# Altium schematic sheet COMMUNICATION.SchDoc
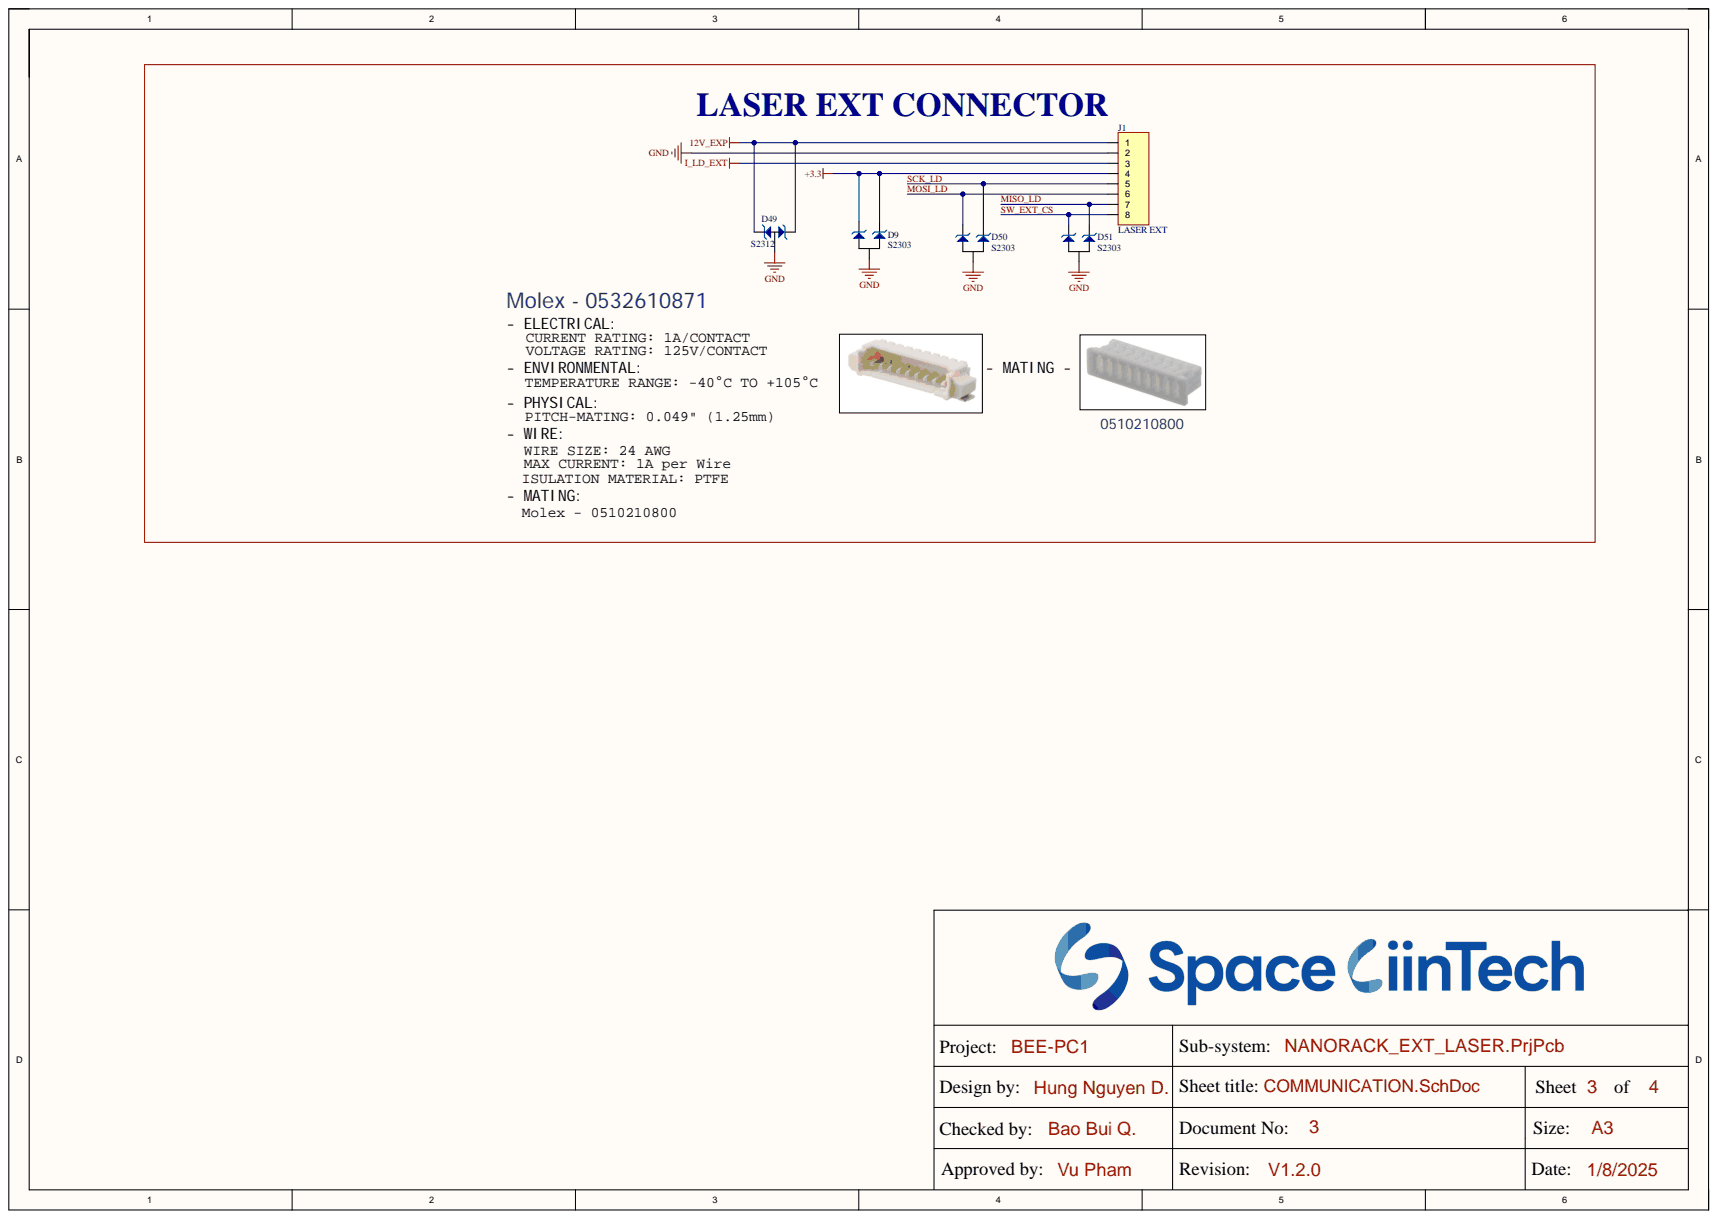
[diagram p0: COMMUNICATION.SchDoc]

## Parts
D9  S2303  [SOT23-123]
D49  S2312  [SOT23-123]
D50  S2303  [SOT23-123]
D51  S2303  [SOT23-123]
J1  LASER EXT  [0532610871]

## Nets
12V_EXP: D49.1 D49.3 J1.1
+3.3: D9.1 D9.3 J1.4
GND: D9.2 D49.2 D50.2 D51.2 J1.2
I_LD_EXT: J1.3
MISO_LD: D51.3 J1.7
MOSI_LD: D50.1 J1.6
SCK_LD: D50.3 J1.5
SW_EXT_CS: D51.1 J1.8

## Library data of the parts
D9 D50 D51 = S2303: TVS DIODE 3.3VWM 8VC SOT23, P/N:    S2303; Component Kind: Standard; Library Name: Library.SchLib
D49 = S2315: TVS DIODE 12VWM 19VC SOT23, P/N:  S2312; Component Kind: Standard; Library Name: Library.SchLib
J1 = JP8: WR-PHD 2.54 mm Angled Socket Header; Manufacturer: W¨¹rth Elektronik eiSos GmbH; partNo: 613008143121; ComponentLink1 Description: Manufacturer; ComponentLink2 Description: Datasheet; NoPins: 08; Component Kind: Standard; ComponentLink1URL: http://www.we-online.com; ComponentLink2URL: http://katalog.we-online.de/em/datasheet/6130xx143121.pdf; Created Date: 29 March 2013; Created by: Karrer Zheng; Library Name: Library_HUNG.SchLib
